# Altium schematic sheet Fanet.SchDoc
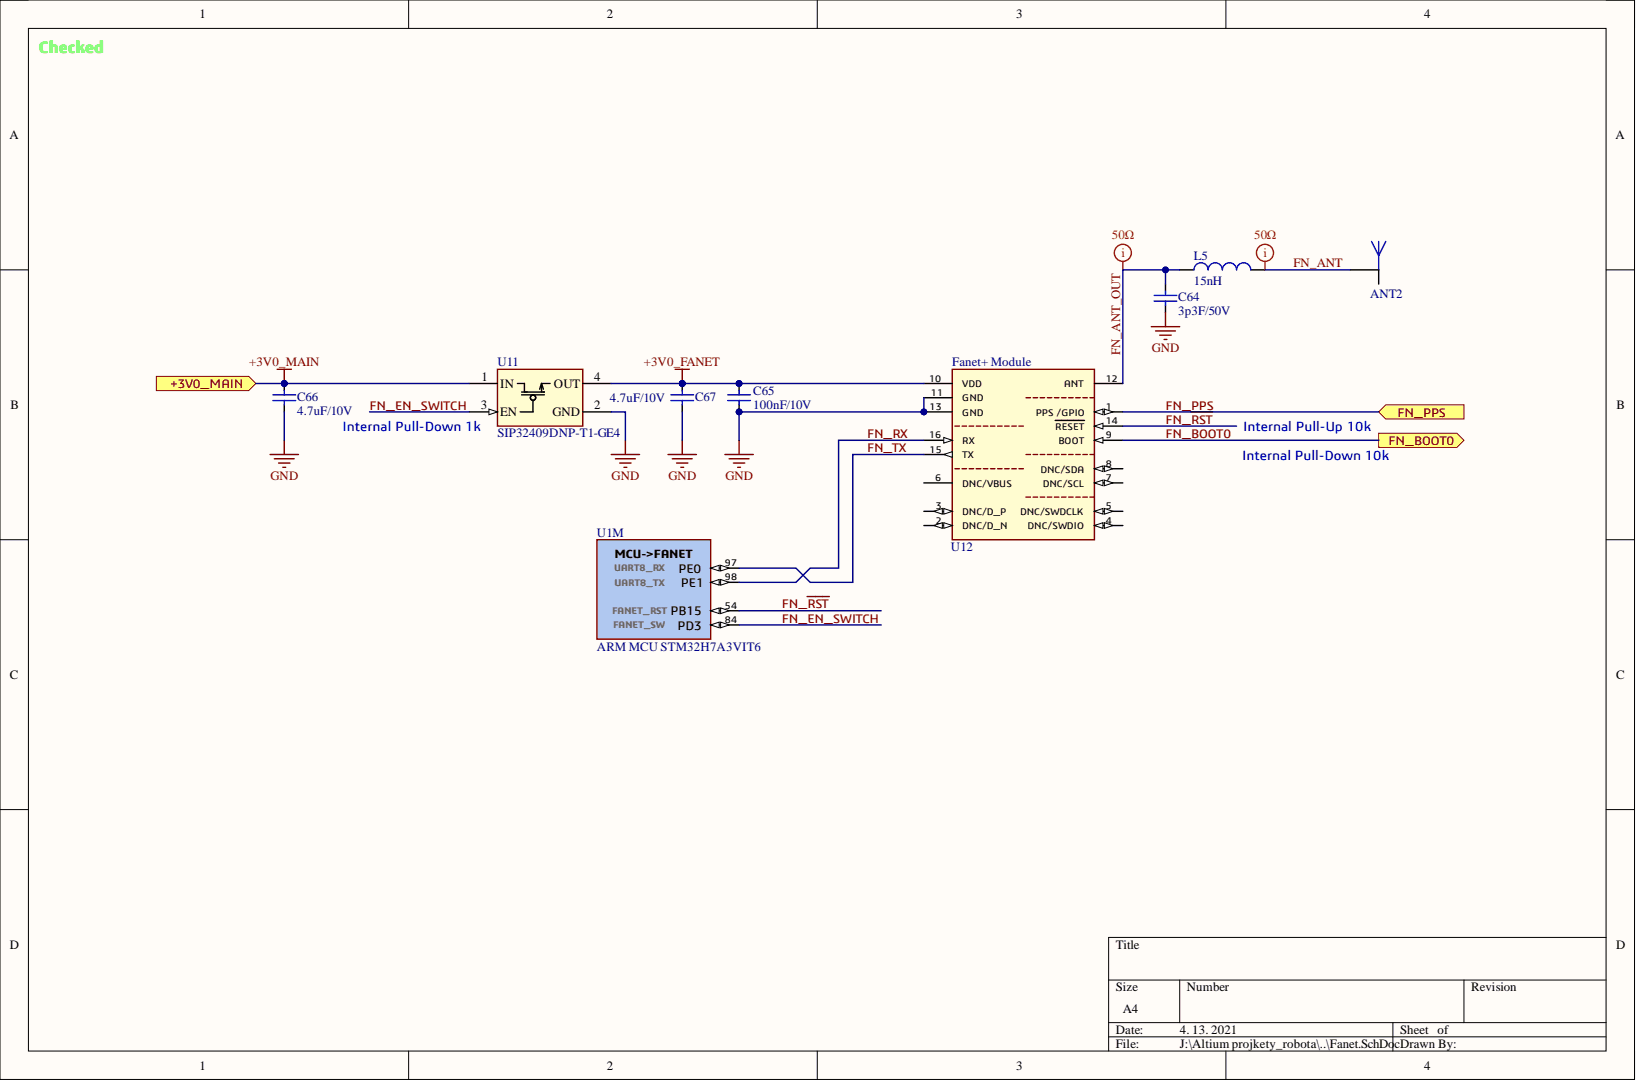
[diagram p0: Fanet.SchDoc]

## Parts
ANT2  W3329  [W3329 PCB]  (value not drawn)
C64  3p3F/50V  [CAPACITOR_0402_(1005)]
C65  100nF/10V  [CAPACITOR_0402_(1005)]
C66  4.7uF/10V  [CAPACITOR_0603_(1608)]
C67  4.7uF/10V  [CAPACITOR_0603_(1608)]
L5  15nH  [INDUCTOR_0402_(1005)]
U1  ARM MCU STM32H7A3VIT6  Manufacturer Part Number: STM32H7A3VIT6  [STM-LQFP100_N - Edited]  (part M)
U11  SIP32409DNP-T1-GE4  [TDFN4]
U12  Fanet+ Module  [Fanet]

## Ports
+3V0_MAIN, FN_BOOT0, FN_PPS

## Nets
+3V0_FANET: C65.2 C67.1 U11.4(OUT) U12.10(VDD)
GND: C64.1 C65.1 C66.2 C67.2 U11.2(GND) U12.11(GND) U12.13(GND)
+3V0_MAIN: C66.1 U11.1(IN) ; port +3V0_MAIN
FN_ANT: ANT2.1 L5.2
FN_ANT_OUT: C64.2 L5.1 U12.12(ANT)
FN_BOOT0: U12.9(BOOT) ; port FN_BOOT0
FN_EN_SWITCH: U1.84(PD3) U11.3(EN)
FN_PPS: U12.1(PPS_/GPIO) ; port FN_PPS
FN_~{RST}: U1.54(PB15) U12.14(RESET)
FN_RX: U1.98(PE1) U12.16(RX)
FN_TX: U1.97(PE0) U12.15(TX)

Not connected: ANT2 2; U12 2(DNC/D_N) 3(DNC/D_P) 4(DNC/SWDIO) 5(DNC/SWDCLK) 6(DNC/VBUS) 7(DNC/SCL) 8(DNC/SDA)

## Library data of the parts
ANT2 = Antenna: Chip Antenna Single Band 50Ohm 868MHz 0.17dBi SMD T/R; Manufacturer: Pulse; Component Kind: Standard; Library Name: BB1_SCH_Library.SchLib; Manufacturer Part Number: W3329; Pricing 1: 1=1,62000, 10=1,46000, 50=1,34000, 100=1,18000, 1000=0,96300, 10000=0,72400 (USD); RoHS: No; Stock 1: 974; Supplier 1: Mouser; Supplier Part Number 1: 673-W3329
C64 = CAPACITOR: ESR: 0.28R; Voltage Rating: 50V; Capacitance: 3p3F/50V; Component Kind: Standard; Description: CAPACITOR; Library Name: RLCFB.SchLib
C65-C67 = CAPACITOR: ESR: 0.28R; Voltage Rating: 10V; Component Kind: Standard; Description: CAPACITOR; Library Name: RLCFB.SchLib
  C65: Capacitance: 100nF/10V
  C66 C67: Capacitance: 4.7uF/10V
L5 = INDUCTOR: INDUCTOR_High_Frequency; DC Current Rating: 2mA; DC Resistance Max: 1R; Component Kind: Standard; Inductance: 15nH; Library Name: RLCFB.SchLib
U1 = STM32H7A3VIT6_LQFP100: STM32H7A3VIT6 -  32-bit Arm® Cortex®-M7 280 MHz MCUs, up to 2-Mbyte Flash memory, 1.4Mbyte RAM, 46 com. and analog interfaces; Manufacturer: STMicroelectronics; Category: Microcontrollers; Component Kind: Standard; ComponentLink1Description: Datasheet; ComponentLink1URL: https://www.st.com/resource/en/datasheet/stm32h7a3vi.pdf; Library Name: BB1_SCH_Library.SchLib; RoHS: No; Supplier 1: Mouser; Supplier Part Number 1: 511-STM32H7A3VIT6
U11 = Load Distribution Switch Power SIP32409DNP-T1-GE4: SIP32409DNP-T1-GE4 -  Power Load Distribution Switch, Active High, 1 Output, 5.5V, 2.3A, 45mohm, TDFN-4 Pins; Manufacturer: Vishay; Fault Protection: Reverse Current; Interface: On/Off; Max Output Current: 3.5A; Max Power Dissipation: 735mW; Min Supply Voltage: 1.1V; Number of Outputs: 1; On-State Resistance: 52mR; Output Configuration: High Side; Turn-Off Delay Time: 1us; Turn-On Delay Time: 1.8ms; Category: Hot Swap Controllers; Component Kind: Standard; ComponentLink1Description: https://datasheet.ciiva.com/41860/sip32408-41860529.pdf?src-supplier=Digi-Key; ComponentLink1URL: https://datasheet.ciiva.com/41860/sip32408-41860529.pdf?src-supplier=Digi-Key; Library Name: BB1_SCH_Library.SchLib; Manufacturer Part Number: SIP32409DNP-T1-GE4; Max Operating Temperature: 125°C; Max Supply Voltage: 5.5V; Min Operating Temperature: -40°C; Mount: Surface Mount; Number of Pins: 4; Output Current: 3.5A; Package Quantity: 3000; Packaging: Tape and Reel; Power Dissipation: 735mW; Pricing 1: 5=0,44900, 50=0,39600, 100=0,29600, 250=0,25000, 500=0,23900, 1000=0,16500, 5000=0,15300, 10000=0,14200 (EUR); Radiation Hardening: No; RoHS: Yes; Stock 1: 645; Supplier 1: Farnell; Supplier Part Number 1: 2889710; Weight: 0.001764oz
U12 = Fanet+ Module: SKYTRAXX FANET+ Module; Manufacturer: Flarm Skytraxx; Component Kind: Standard; ComponentLink1Description: Datasheet; ComponentLink1URL: https://github.com/3s1d/fanet-stm32/blob/master/fanet_module.pdf; Library Name: BB1_SCH_Library.SchLib
